# Altium schematic sheet Input-Comparator.SchDoc(IN)
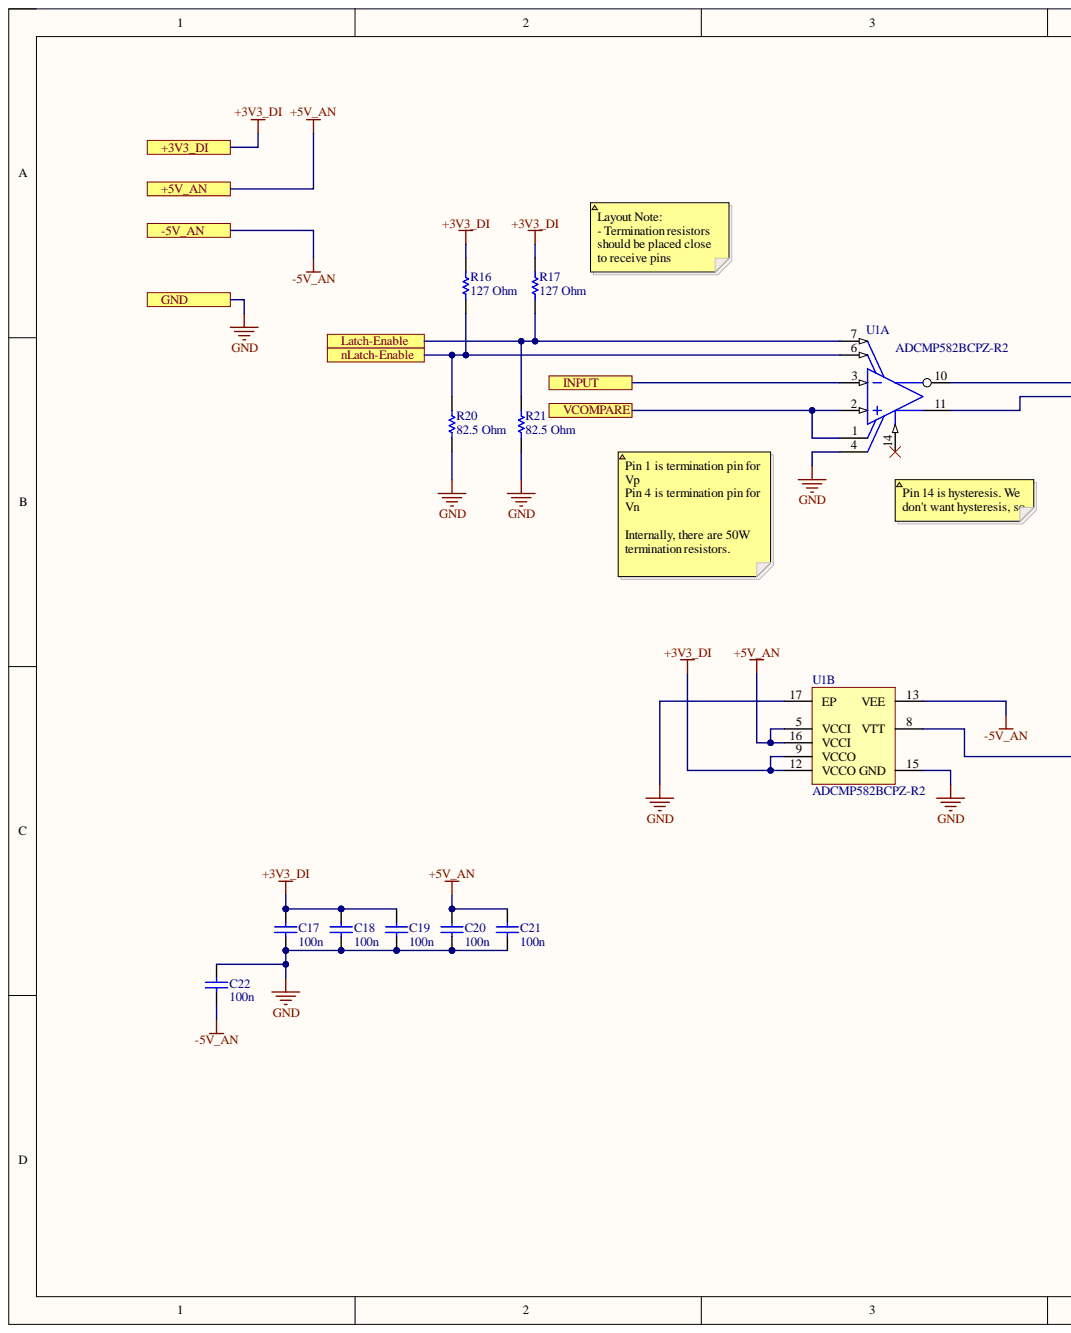
[diagram p0: Input-Comparator.SchDoc, left]
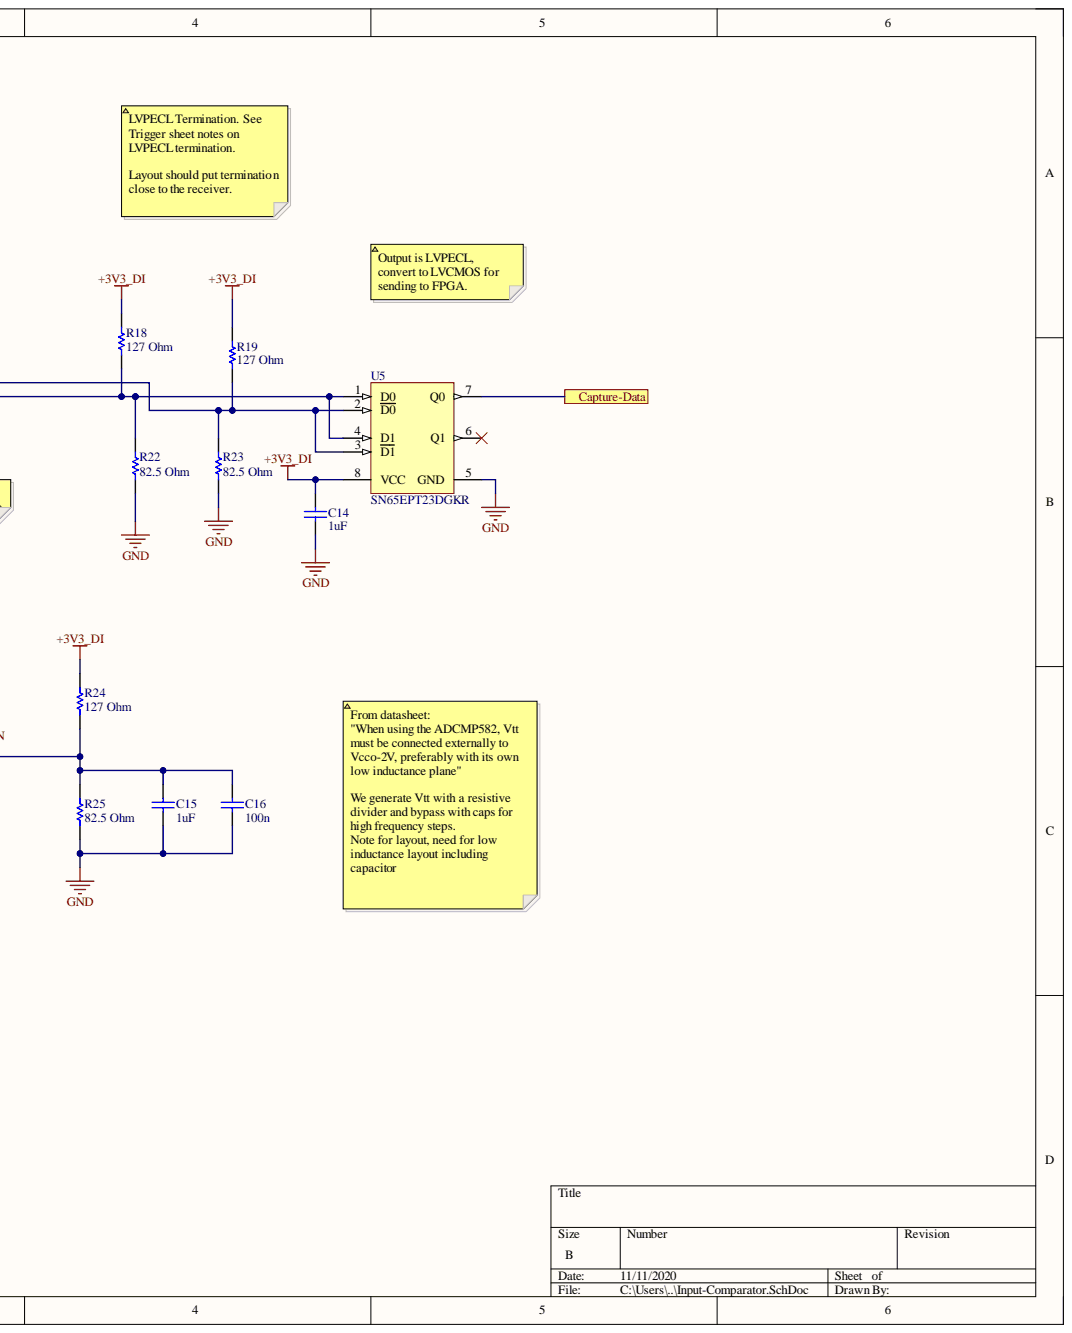
[diagram p1: Input-Comparator.SchDoc, right]

## Parts
C14  1uF  [CAPC2013X100X50NL20T25]
C15  1uF  [CAPC2013X100X50NL20T25]
C16  value 100n  [CAPC2013X88X50NL20T25]  (hidden comment: C0805C104K5RACTU)
C17  value 100n  [CAPC2013X88X50NL20T25]  (hidden comment: C0805C104K5RACTU)
C18  value 100n  [CAPC2013X88X50NL20T25]  (hidden comment: C0805C104K5RACTU)
C19  value 100n  [CAPC2013X88X50NL20T25]  (hidden comment: C0805C104K5RACTU)
C20  value 100n  [CAPC2013X88X50NL20T25]  (hidden comment: C0805C104K5RACTU)
C21  value 100n  [CAPC2013X88X50NL20T25]  (hidden comment: C0805C104K5RACTU)
C22  value 100n  [CAPC2013X88X50NL20T25]  (hidden comment: C0805C104K5RACTU)
R16  127 Ohm  [RESC2013X50X30NL20T20]
R17  127 Ohm  [RESC2013X50X30NL20T20]
R18  127 Ohm  [RESC2013X50X30NL20T20]
R19  127 Ohm  [RESC2013X50X30NL20T20]
R20  82.5 Ohm  [RESC2013X50X30ML20T20]
R21  82.5 Ohm  [RESC2013X50X30ML20T20]
R22  82.5 Ohm  [RESC2013X50X30ML20T20]
R23  82.5 Ohm  [RESC2013X50X30ML20T20]
R24  127 Ohm  [RESC2013X50X30NL20T20]
R25  82.5 Ohm  [RESC2013X50X30ML20T20]
U1  ADCMP582BCPZ-R2  [ADI-CP-16-3_L]  (parts A,B)
U5  SN65EPT23DGKR  [DGK0008A_L]

## Ports
+3V3_DI, +5V_AN, -5V_AN, Capture-Data, GND, INPUT, Latch-Enable, nLatch-Enable, VCOMPARE

## Nets
+3V3_DI: C14.1 C17.2 C18.2 C19.2 R16.2 R17.2 R18.2 R19.2 R24.2 U1.9(VCCO) U1.12(VCCO) U5.8(VCC) ; port +3V3_DI
+5V_AN: C20.2 C21.2 U1.5(VCCI) U1.16(VCCI) ; port +5V_AN
-5V_AN: C22.1 U1.13(VEE) ; port -5V_AN
Capture-Data: U5.7(Q0) ; port Capture-Data
GND: C14.2 C15.1 C16.1 C17.1 C18.1 C19.1 C20.1 C21.1 C22.2 R20.1 R21.1 R22.1 R23.1 R25.1 U1.4 U1.15(GND) U1.17(EP) U5.5(GND) ; port GND
INPUT: U1.3 ; port INPUT
Latch-Enable: R17.1 R21.2 U1.7 ; port Latch-Enable
nLatch-Enable: R16.1 R20.2 U1.6 ; port nLatch-Enable
VCOMPARE: U1.1 U1.2 ; port VCOMPARE
NetC15_2: C15.2 C16.2 R24.1 R25.2 U1.8(VTT)
NetR18_1: R18.1 R22.2 U1.11 U5.1(D0) U5.4(D1)
NetR19_1: R19.1 R23.2 U1.10 U5.2(D0) U5.3(D1)
NetU1_14: U1.14
NetU5_6: U5.6(Q1)

## Library data of the parts
C14 C15 = CMP-2007-03716-1: Manufacturer: Kemet; Part Number: C0805X105K3RACTU; Component Kind: Standard; Library Name: Altium Content Vault
C16-C22 = CMP-2007-00279-1: Manufacturer: Kemet; Component Kind: Standard; Library Name: Altium Content Vault; Part Number: C0805C104K5RACTU
R16-R19 R24 = CMP-2001-04933-1: Manufacturer: Vishay Dale; Part Number: CRCW0805127RFKEA; Component Kind: Standard; Library Name: Altium Content Vault
R20-R23 R25 = CMP-2001-04138-1: Manufacturer: Vishay Dale; Part Number: CRCW080582R5FKEA; Component Kind: Standard; Library Name: Altium Content Vault
U1 = CMP-0783-00019-1: Ultrafast SiGe Voltage Comparator, + / - 5 V, 180 ps Delay, Industrial, 16-pin QFN (CP-16-3), Reel; Manufacturer: Analog Devices; Input Range (V): -2 to 3; Voltage Supply (V): 5 to -5; Code_JEDEC: MO-220-VEED-2; Component Kind: Standard; ComponentLink1Description: Manufacturer URL; ComponentLink1URL: http://www.analog.com/en/index.html; ComponentLink2Description: Datasheet; ComponentLink2URL: http://www.analog.com/static/imported-files/data_sheets/ADCMP580_581_582.pdf; ComponentLink3Description: Package Specification; ComponentLink3URL: http://www.analog.com/static/imported-files/packages/PKG_PDF/LFCSP(CP)/CP_16_3.pdf; ComponentLink4Description: URL Link1; ComponentLink4URL: Product URL:http://www.analog.com/en/special-linear-functions/comparators/adcmp582/products/product.html; DatasheetVersion: Rev. A; Library Name: Altium Content Vault; Mounting Technology: Surface Mount; PackageDescription: 16-Pin Lead Frame Chip Scale Package, Body 3 x 3 mm, Pitch 0.5 mm; PackageReference: CP-16-3; Packing: Reel; PartNumber: ADCMP582BCPZ-R2
U5 = CMP-0327-00168-3: 600 Mbps 3.3 V ECL Differential Receiver with LVPECL, LVDS Input and LVTTL, CMOS Output, 2 Tx and 2 Rx, -40 to +85 degC, 8-pin VSSOP (DGK), Green (RoHS & no Sb/Br); Manufacturer: Texas Instruments; ICC(Max)(mA): 25; No. of Rx: 2; Signaling Rate(Mbps): 600; SubFamily: PECL PHY; xECL: TRUE; Component Kind: Standard; ComponentLink1Description: Manufacturer URL; ComponentLink1URL: http://www.ti.com/; ComponentLink2Description: Datasheet; ComponentLink2URL: http://www.ti.com/general/docs/lit/getliterature.tsp?genericPartNumber=SN65EPT23&fileType=pdf; ComponentLink3Description: URL Link1; ComponentLink3URL: SamplesURL:http://www.ti.com/product/sn65ept23#samples; DatasheetVersion: SLLS969A; Eco-Plan: Green (RoHS & no Sb/Br); Input Signal: LVPECL, LVDS; Library Name: Altium Content Vault; Mounting Technology: Surface Mount; No. of Tx: 2; Output Signal: LVTTL, CMOS; PackageDescription: 8-Pin Thin Small Outline Package 3 x 3 mm Body ,0.65 mm Pitch; PackageReference: DGK0008A; PackageVersion: revE, May-2006; PartNumber: SN65EPT23DGKR
